# Altium schematic sheet Debug.SchDoc(Debug)
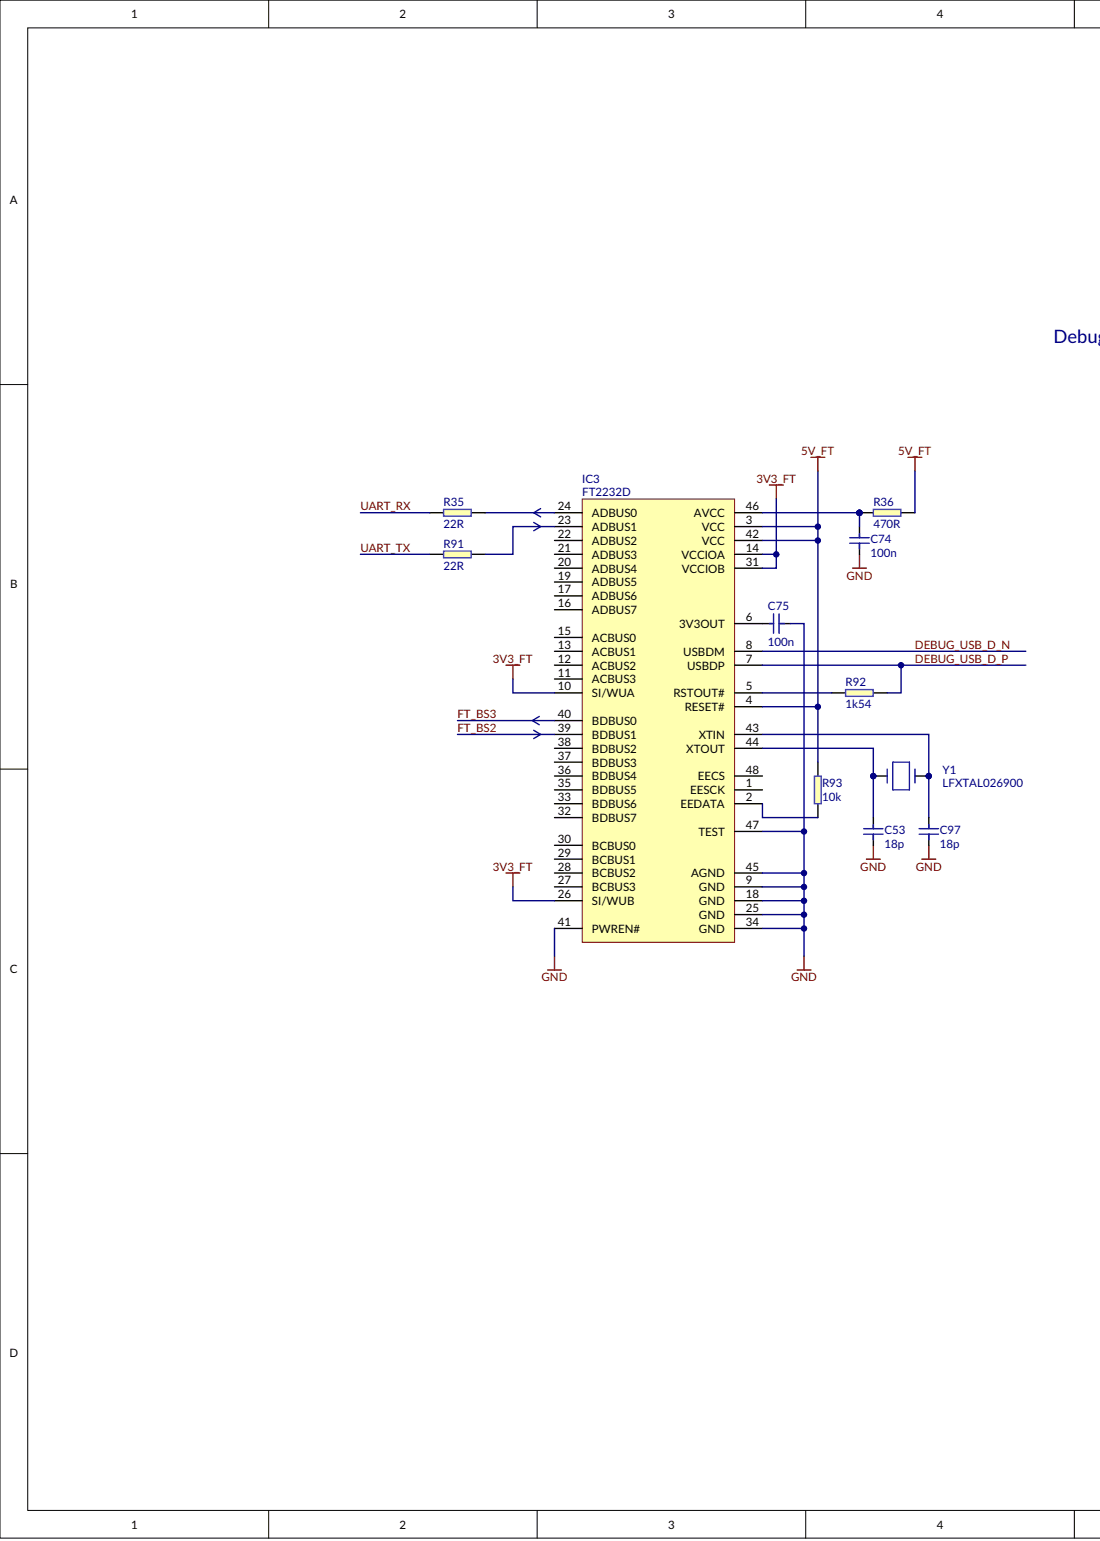
[diagram p0: Debug.SchDoc, left]
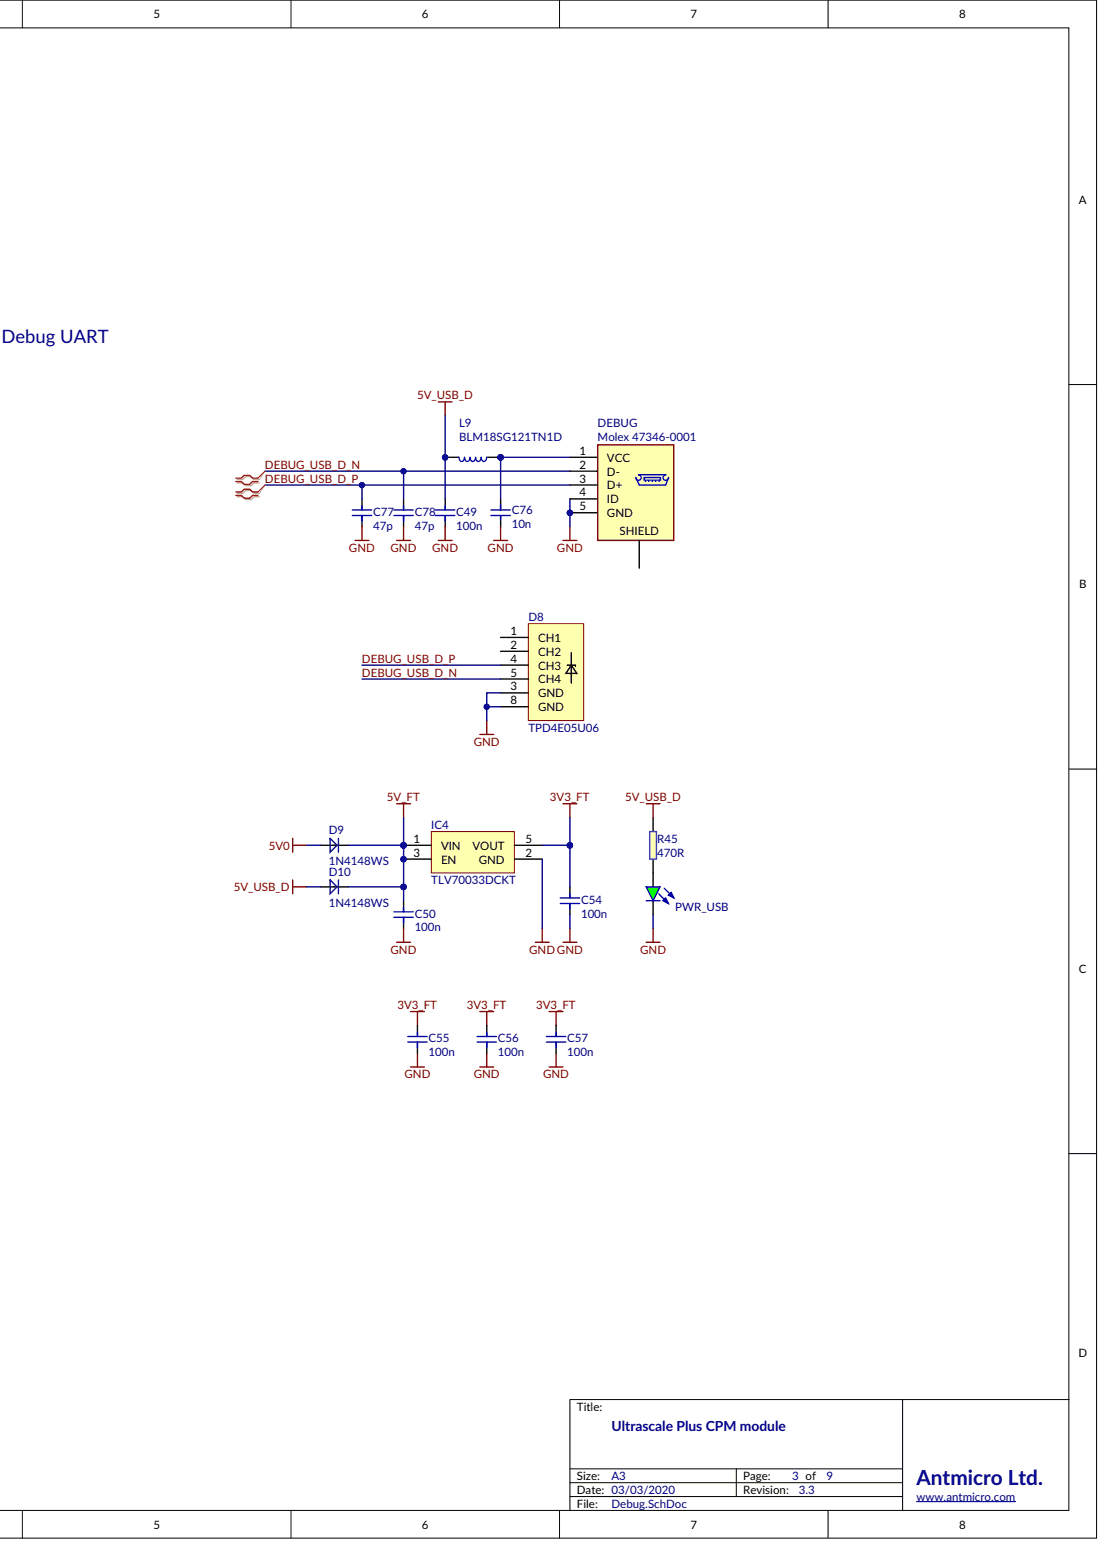
[diagram p1: Debug.SchDoc, right]

## Parts
C49  100n
C50  100n
C53  18p
C54  100n
C55  100n
C56  100n
C57  100n
C74  100n
C75  100n
C76  10n
C77  47p
C78  47p
C97  18p
D8  TPD4E05U06
D9  1N4148WS
D10  1N4148WS
DEBUG  Manufacturer: Molex  (hidden comment: Molex 47346-0001)
IC3  FT2232D
IC4  TLV70033DCKT
L9  BLM18SG121TN1D
PWR_USB  KPT-1608SGC  (value not drawn)
R35  22R
R36  470R
R45  470R
R91  22R
R92  1k54
R93  10k
Y1  LFXTAL026900

## Nets
3V3_FT: C54.2 C55.2 C56.2 C57.2 IC3.10(SI/WUA) IC3.14(VCCIOA) IC3.26(SI/WUB) IC3.31(VCCIOB) IC4.5(VOUT)
5V0: D9.A
5V_FT: C50.2 D9.C D10.C IC3.3(VCC) IC3.4(RESET#) IC3.42(VCC) IC4.1(VIN) IC4.3(EN) R36.1 R93.2
5V_USB_D: C49.2 D10.A L9.1 R45.2
GND: C49.1 C50.1 C53.1 C54.1 C55.1 C56.1 C57.1 C74.1 C75.1 C76.2 C77.1 C78.1 C97.1 D8.3(GND) D8.8(GND) DEBUG.4(ID) DEBUG.5(GND) IC3.9(GND) IC3.18(GND) IC3.25(GND) IC3.34(GND) IC3.41(PWREN#) IC3.45(AGND) IC3.47(TEST) IC4.2(GND) PWR_USB.K
DEBUG_USB_D_N: C78.2 D8.5(CH4) DEBUG.2(D-) IC3.8(USBDM)
DEBUG_USB_D_P: C77.2 D8.4(CH3) DEBUG.3(D+) IC3.7(USBDP) R92.1
FT_BS2: IC3.39(BDBUS1)
FT_BS3: IC3.40(BDBUS0)
UART_RX: R35.1
UART_TX: R91.1
NetC53_2: C53.2 IC3.44(XTOUT) Y1.2
NetC74_2: C74.2 IC3.46(AVCC) R36.2
NetC75_2: C75.2 IC3.6(3V3OUT)
NetC76_1: C76.1 DEBUG.1(VCC) L9.2
NetC97_2: C97.2 IC3.43(XTIN) Y1.1
NetIC3_2: IC3.2(EEDATA) R93.1
NetIC3_5: IC3.5(RSTOUT#) R92.2
NetIC3_23: IC3.23(ADBUS1) R91.2
NetIC3_24: IC3.24(ADBUS0) R35.2
NetPWR_USB_A: PWR_USB.A R45.1

Not connected: D8 1(CH1) 2(CH2); DEBUG S; IC3 1(EESCK) 11(ACBUS3) 12(ACBUS2) 13(ACBUS1) 15(ACBUS0) 16(ADBUS7) 17(ADBUS6) 19(ADBUS5) 20(ADBUS4) 21(ADBUS3) 22(ADBUS2) 27(BCBUS3) 28(BCBUS2) 29(BCBUS1) 30(BCBUS0) 32(BDBUS7) 33(BDBUS6) 35(BDBUS5) 36(BDBUS4) 37(BDBUS3) 38(BDBUS2) 48(EECS)

## Library data of the parts
C49 = (no symbol name): Part Number: C_100n_0603; Manufacturer: WALSIN; Part Symbol: 0603B104K500CT; Value: 100n; Supplier 1: Farnell; Supplier Part Number 1: 2496834
C50 C54-C57 C74 C75 = (no symbol name): Part Number: C_100n_0402; Manufacturer: MULTICOMP; Part Symbol: MC0402X104M100CT; Value: 100n; Supplier 1: Farnell; Supplier Part Number 1: 2309011
C53 C97 = (no symbol name): Part Number: C_18p_0402; Manufacturer: KEMET; Part Symbol: C0402C180J5GACAUTO; Value: 18p; Supplier 1: Farnell; Supplier Part Number 1: 2070379
C76 = (no symbol name): Part Number: C_10n_0603; Manufacturer: AVX; Part Symbol: 06031C103JAT2A; Value: 10n; Supplier 1: Farnell; Supplier Part Number 1: 1833876
C77 C78 = (no symbol name): Part Number: C_47p_0402; Manufacturer: KEMET; Part Symbol: C0402C470J5GACTU; Value: 47p; Supplier 1: Farnell; Supplier Part Number 1: 1414592
D8 = (no symbol name): Part Number: TPD4E05U06_PASS; Manufacturer: Texas Instruments; Part Symbol: TPD4E05U06DQAR; Value: TPD4E05U06; Supplier 1: Digi-Key; Supplier Part Number 1: 296-35765-1-ND
D9 D10 = (no symbol name): Manufacturer: MULTICOMP; Part Number: 1N4148WS; Value: 1N4148WS; Supplier 1: Farnell; Supplier Part Number 1: 1466524; Part Symbol: 1N4148WS
DEBUG = (no symbol name): Part Number: CON-Molex-47346-0001; Part Symbol: 473460001; Supplier Part Number 1: WM17141TR-ND; Value: Molex 47346-0001; Supplier 1: Digi-Key
IC3 = (no symbol name): Manufacturer: FTDI; Part Symbol: FT2232D-REEL; Part Number: FT2232D; Value: FT2232D; Supplier 1: Farnell; Supplier Part Number 1: 1615843
IC4 = (no symbol name): Manufacturer: TEXAS INSTRUMENTS; Part Number: TLV70033DCKT; Value: TLV70033DCKT; Supplier 1: Farnell; Supplier Part Number 1: 1815750; Part Symbol: TLV70033DCKT
L9 = (no symbol name): Manufacturer: MURATA; Rated Current: 3A; Part Number: BLM18SG121TN1D; Value: BLM18SG121TN1D; Supplier 1: Farnell; Supplier Part Number 1: 1515752; Part Symbol: BLM18SG121TN1D
PWR_USB = (no symbol name): Part Number: LED_G; Manufacturer: KINGBRIGHT; Value: KPT-1608SGC; Supplier 1: Farnell; Supplier Part Number 1: 2099223; Part Symbol: KPT-1608SGC
R35 R91 = (no symbol name): Part Number: R_22R_0402; Manufacturer: VISHAY; Part Symbol: CRCW040222R0FKED; Tolerance: 1%; Value: 22R; Supplier 1: Farnell; Supplier Part Number 1: 1469697
R36 = (no symbol name): Part Number: R_470R_0402; Manufacturer: VISHAY; Part Symbol: CRCW0402470RFKED.; Value: 470R; Supplier 1: Farnell; Supplier Part Number 1: 2140742
R45 = (no symbol name): Part Number: R_470R_0603; Manufacturer: BOURNS; Part Symbol: CR0603-JW-471GLF; Value: 470R; Supplier 1: Farnell; Supplier Part Number 1: 2008354
R92 = (no symbol name): Part Number: R_1k54_0402; Manufacturer: VISHAY; Part Symbol: CRCW04021K54FKED.; Tolerance: 1%; Value: 1k54; Supplier 1: Farnell; Supplier Part Number 1: 2140790
R93 = (no symbol name): Part Number: R_10k_0402; Manufacturer: MULTICOMP; Part Symbol: MCMR04X1002FTL; Tolerance: 1%; Value: 10k; Supplier 1: Farnell; Supplier Part Number 1: 2072517
Y1 = (no symbol name): Part Number: CRYSTAL_6MHz_SMD; Manufacturer: IQD FREQUENCY PRODUCTS; Value: LFXTAL026900; Supplier 1: Farnell; Supplier Part Number 1: 2449403; Part Symbol: LFXTAL026900
